# Altium schematic sheet nRF52840.SchDoc
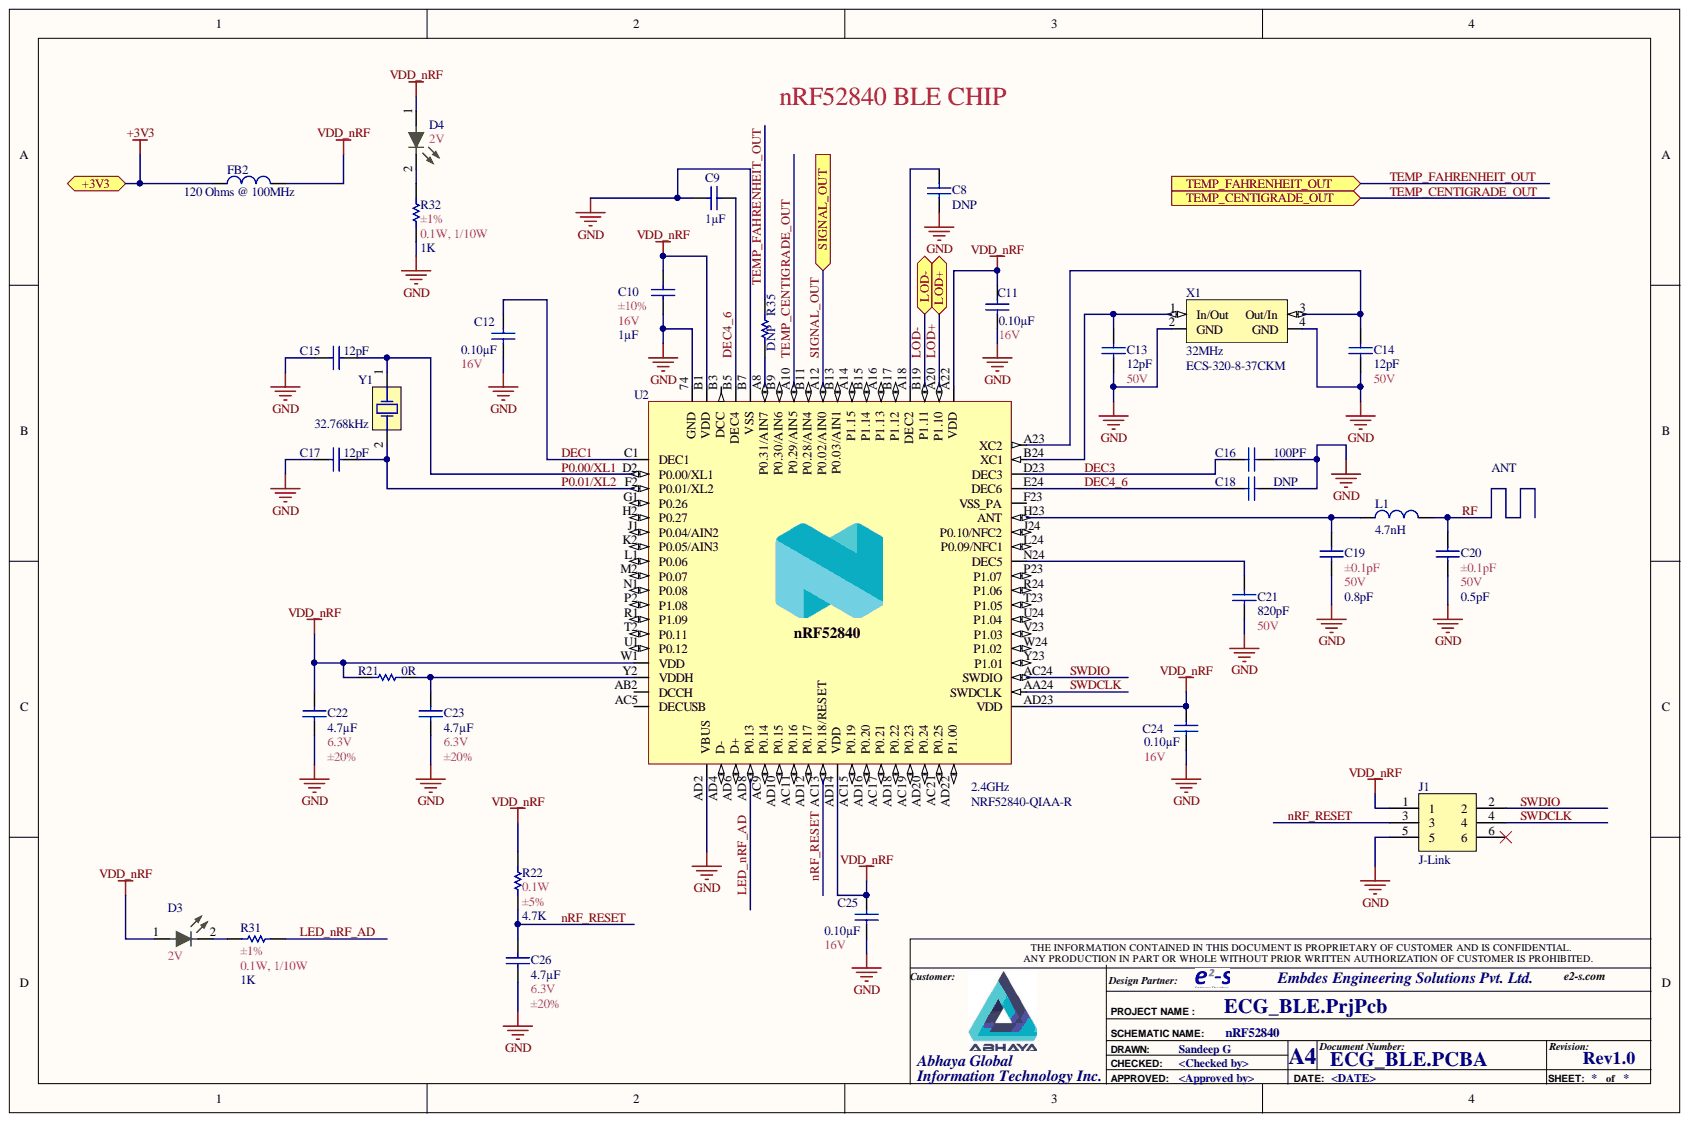
[diagram p0: nRF52840.SchDoc]

## Parts
C8  value DNP  Manufacturer Part Number: DNP  [SMDC0402]  (hidden comment: CAP CER 100PF 25V X7RCAP CER 100PF 25V C0G/NP0 0402 0402)
C9  value 1µF  [SMDC0402]  (hidden comment: CAP CER 1UF 16V X5R 0402)
C10  value 1µF  1st Auxiliary Field: 16V  2nd Auxiliary Field: ±10%  [SMDC0402]  (hidden comment: CAP CER 1UF 16V X5R 0402)
C11  value 0.10µF  1st Auxiliary Field: 16V  [SMDC0402]  (hidden comment: CAP CER 0.1UF 16V X7R 0402)
C12  value 0.10µF  1st Auxiliary Field: 16V  [SMDC0402]  (hidden comment: CAP CER 0.1UF 16V X7R 0402)
C13  value 12pF  1st Auxiliary Field: 50V  [SMDC0402]  (hidden comment: CAP CER 12PF 50V C0G/NP0 0402)
C14  value 12pF  1st Auxiliary Field: 50V  [SMDC0402]  (hidden comment: CAP CER 12PF 50V C0G/NP0 0402)
C15  value 12pF  [SMDC0402]  (hidden comment: CAP CER 12PF 50V C0G/NP0 0402)
C16  value 100PF  [SMDC0402]  (hidden comment: CAP CER 100PF 25V X7RCAP CER 100PF 25V C0G/NP0 0402 0402)
C17  value 12pF  [SMDC0402]  (hidden comment: CAP CER 12PF 50V C0G/NP0 0402)
C18  value DNP  Manufacturer Part Number: DNP  [SMDC0402]  (hidden comment: CAP CER 100PF 25V X7RCAP CER 100PF 25V C0G/NP0 0402 0402)
C19  value 0.8pF  1st Auxiliary Field: 50V  2nd Auxiliary Field: ±0.1pF  [SMDC0402]  (hidden comment: CAP CER 0.8PF 50V C0G/NP0 0402)
C20  value 0.5pF  1st Auxiliary Field: 50V  2nd Auxiliary Field: ±0.1pF  [SMDC0402]  (hidden comment: CAP CER 0.5PF 50V C0G/NP0 0402)
C21  value 820pF  1st Auxiliary Field: 50V  [SMDC0402]  (hidden comment: CAP CER 820PF 50V C0G/NP0 0402)
C22  value 4.7µF  1st Auxiliary Field: 6.3V  2nd Auxiliary Field: ±20%  [SMDC0402]  (hidden comment: CAP CER 4.7UF 6.3V X5R 0402)
C23  value 4.7µF  1st Auxiliary Field: 6.3V  2nd Auxiliary Field: ±20%  [SMDC0402]  (hidden comment: CAP CER 4.7UF 6.3V X5R 0402)
C24  value 0.10µF  1st Auxiliary Field: 16V  [SMDC0402]  (hidden comment: CAP CER 0.1UF 16V X7R 0402)
C25  value 0.10µF  1st Auxiliary Field: 16V  [SMDC0402]  (hidden comment: CAP CER 0.1UF 16V X7R 0402)
C26  value 4.7µF  1st Auxiliary Field: 6.3V  2nd Auxiliary Field: ±20%  [SMDC0402]  (hidden comment: CAP CER 4.7UF 6.3V X5R 0402)
D3  1st Auxiliary Field: 2V  [SMDLED0603]  (hidden comment: LED GREEN CLEAR SMD)
D4  1st Auxiliary Field: 2V  [SMDLED0603]  (hidden comment: LED GREEN CLEAR SMD)
FB2  value 120 Ohms @ 100MHz  [SMDL0402]  (hidden comment: FERRITE BEAD 120 OHM 0402 1LN)
J1  1st Auxiliary Field: J-Link  [PRG_8.06.16 J-LINK 6-PIN NEEDLE ADAPTER]  (hidden comment: J-LINK 6-PIN NEEDLE ADAPTER)
L1  value 4.7nH  [SMDL0402]  (hidden comment: FIXED IND 4.7NH 160MA 600 MOHM)
R21  value 0R  [SMDR0402]  (hidden comment: RES SMD 0.0OHM JUMPER 1/10W 0402)
R22  value 4.7K  1st Auxiliary Field: 0.1W  2nd Auxiliary Field: ±5%  [SMDR0402]  (hidden comment: RES SMD 4.7K OHM 5% 1/10W 0402)
R31  value 1K  1st Auxiliary Field: 0.1W, 1/10W  2nd Auxiliary Field: ±1%  [SMDR0402]  (hidden comment: RES SMD 1K OHM 1% 1/10W 0402)
R32  value 1K  2nd Auxiliary Field: ±1%  [SMDR0402]  (hidden comment: RES SMD 1K OHM 1% 1/10W 0402)
R35  value DNP  [SMDR0402]  (hidden comment: RES SMD 0.0OHM JUMPER 1/10W 0402)
U2  value NRF52840-QIAA-R  1st Auxiliary Field: 2.4GHz  Manufacturer Part Number: NRF52840-QIAA-R  [AQFN50P700X700X85_HS-74N]  (hidden comment: IC RF TXRX+MCU 802.15.4 73VFQFN)
X1  value ECS-320-8-37CKM  1st Auxiliary Field: 32MHz  Manufacturer Part Number: ECS-320-8-37CKM  [XTAL200X160X50-4N]  (hidden comment: CRYSTAL 32.0000MHZ 8PF SMD)
Y1  1st Auxiliary Field: 32.768kHz  [XTAL325X155X80N]  (hidden comment: CRYSTAL 32.7680KHZ 12.5PF SMD)

## Ports
+3V3, LOD+, LOD-, SIGNAL_OUT, TEMP_CENTIGRADE_OUT, TEMP_FAHRENHEIT_OUT

## Nets
GND: C8.2 C9.1 C10.1 C11.1 C12.1 C13.1 C14.1 C15.1 C16.2 C17.1 C18.2 C19.1 C20.1 C21.1 C22.1 C23.1 C24.1 C25.1 C26.1 J1.5 R32.2 U2.74(GND) U2.AD2(VBUS) U2.B7(VSS) X1.2(GND) X1.4(GND)
VDD_nRF: C10.2 C11.2 C22.2 C24.2 C25.2 D3.1 D4.1 FB2.2 J1.1 R21.1 R22.2 U2.A22(VDD) U2.AD14(VDD) U2.AD23(VDD) U2.B1(VDD) U2.W1(VDD)
+3V3: FB2.1 ; port +3V3
DEC1: C12.2 U2.C1(DEC1)
DEC3: C16.1 U2.D23(DEC3)
DEC4_6: C9.2 C18.1 U2.B5(DEC4) U2.E24(DEC6)
LED_nRF_AD: R31.1 U2.AD8(P0.13)
LOD+: U2.A20(P1.10) ; port LOD+
LOD-: U2.B19(P1.11) ; port LOD-
nRF_RESET: C26.2 J1.3 R22.1 U2.AC13(P0.18/RESET)
P0.00/XL1: C15.2 U2.D2(P0.00/XL1) Y1.1
P0.01/XL2: C17.2 U2.F2(P0.01/XL2) Y1.2
RF: C20.2 L1.2
SIGNAL_OUT: U2.A12(P0.02/AIN0) ; port SIGNAL_OUT
SWDCLK: J1.4 U2.AA24(SWDCLK)
SWDIO: J1.2 U2.AC24(SWDIO)
TEMP_CENTIGRADE_OUT: U2.A10(P0.29/AIN5) ; port TEMP_CENTIGRADE_OUT
TEMP_FAHRENHEIT_OUT: R35.2 ; port TEMP_FAHRENHEIT_OUT
NetC8_1: C8.1 U2.A18(DEC2)
NetC13_2: C13.2 U2.B24(XC1) X1.1(In/Out)
NetC14_2: C14.2 U2.A23(XC2) X1.3(Out/In)
NetC19_2: C19.2 L1.1 U2.H23(ANT)
NetC21_2: C21.2 U2.N24(DEC5)
NetC23_2: C23.2 R21.2 U2.Y2(VDDH)
NetD3_2: D3.2 R31.2
NetD4_2: D4.2 R32.1
NetR35_1: R35.1 U2.A8(P0.31/AIN7)

Not connected: J1 6; U2 A14(P1.15) A16(P1.13) AB2(DCCH) AC5(DECUSB) AC9(P0.14) AC11(P0.16) AC15(P0.19) AC17(P0.21) AC19(P0.23) AC21(P0.25) AD4(D-) AD6(D+) AD10(P0.15) AD12(P0.17) AD16(P0.20) AD18(P0.22) AD20(P0.24) AD22(P1.00) B3(DCC) B9(P0.30/AIN6) B11(P0.28/AIN4) B13(P0.03/AIN1) B15(P1.14) B17(P1.12) F23(VSS_PA) G1(P0.26) H2(P0.27) J1(P0.04/AIN2) J24(P0.10/NFC2) K2(P0.05/AIN3) L1(P0.06) L24(P0.09/NFC1) M2(P0.07) N1(P0.08) P2(P1.08) P23(P1.07) R1(P1.09) R24(P1.06) T2(P0.11) T23(P1.05) U1(P0.12) U24(P1.04) V23(P1.03) W24(P1.02) Y23(P1.01)

## Library data of the parts
C8 C18 = ECA100P0K25V0-SB: CAP CER 100PF 25V C0G/NP0 0402; Manufacturer: KEMET; Vendor Part Number: 399-1022-1-ND; 2nd Auxiliary Field: ±5%; 3rd Auxiliary Field: ROHS3 Compliant; Component Height (mm): 0.55mm; Temp Co: -55°C ~ 125°C; Vendor Name: Digi-Key; 1st Auxiliary Field: 25V; Component Kind: Standard; Internal Part Number: ECA100P0K25V0-SB; Library Name: Master_ Library.SchLib; Part Type: CAP CER 100PF 25V C0G/NP0 0402
C9 = ECA1U00L16V0-SB: Manufacturer: Samsung Electro-Mechanics; Manufacturer Part Number: CL05A105KO5NNNC; Vendor Part Number: 1276-1067-1-ND; 2nd Auxiliary Field: ±10%; 3rd Auxiliary Field: RoHS Compliant; Component Height (mm): 0.55MM; Vendor Name: Digi-Key; 1st Auxiliary Field: 16V; Component Kind: Standard; Description: CAP CER 1UF 16V X5R 0402; Internal Part Number: ECA1U00L16V0-SB; Library Name: Integrated_Master_Library.IntLib; Part Type: CAP CER 1UF 16V X5R 0402; Temp Co: X5R
C10 = ECA1U00L16V0-SB: Manufacturer: Samsung Electro-Mechanics; Manufacturer Part Number: CL05A105KO5NNNC; Vendor Part Number: 1276-1067-1-ND; 3rd Auxiliary Field: RoHS Compliant; Component Height (mm): 0.55MM; Vendor Name: Digi-Key; Component Kind: Standard; Description: CAP CER 1UF 16V X5R 0402; Internal Part Number: ECA1U00L16V0-SB; Library Name: Integrated_Master_Library.IntLib; Part Type: CAP CER 1UF 16V X5R 0402; Temp Co: X5R
C11 C12 C24 C25 = ECA0U10K16V0-1-SB: Manufacturer: Samsung Electro-Mechanics America, Inc.; Manufacturer Part Number: CL05B104KO5NNNC; Vendor Part Number: 1276-1001-1-ND; 2nd Auxiliary Field: ±10%; Vendor Name: Digi-Key; Component Kind: Standard; Description: CAP CER 0.1UF 16V X7R 0402; Internal Part Number: ECA0U10K16V0-1-SB; Library Name: Master_ Library.SchLib; Part Type: CAP CER 0.1UF 16V X7R 0402; Temp Co: X7R
C13-C15 C17 = ECA12P0K50V0-SB: Manufacturer: KEMET; Manufacturer Part Number: CBR04C120J5GAC; Vendor Part Number: 399-6169-1-ND; 2nd Auxiliary Field: ±5%; 3rd Auxiliary Field: RoHS Compliant; Component Height (mm): 0.55mm; Temp Co: -55°C ~ 125°C; Vendor Name: Digi-Key; Component Kind: Standard; Description: CAP CER 12PF 50V C0G/NP0 0402; Internal Part Number: ECA12P0K50V0-SB; Part Type: CAP CER 12PF 50V C0G/NP0 0402
  C13 C14: Library Name: Integrated_Master_Library.IntLib
  C15 C17: 1st Auxiliary Field: 50V; Library Name: Master_ Library.SchLib
C16 = ECA100P0K25V0-SB: CAP CER 100PF 25V C0G/NP0 0402; Manufacturer: KEMET; Manufacturer Part Number: C0402C101J3GACTU; Vendor Part Number: 399-1022-1-ND; 2nd Auxiliary Field: ±5%; 3rd Auxiliary Field: ROHS3 Compliant; Component Height (mm): 0.55mm; Temp Co: -55°C ~ 125°C; Vendor Name: Digi-Key; 1st Auxiliary Field: 25V; Component Kind: Standard; Internal Part Number: ECA100P0K25V0-SB; Library Name: Master_ Library.SchLib; Part Type: CAP CER 100PF 25V C0G/NP0 0402
C19 = ECA0P8W50V-SB: Internal Part Number: ECA00P8W50V-SB; Manufacturer: Murata Electronics; Manufacturer Part Number: GJM1555C1HR80BB01D; Vendor Part Number: 490-6080-1-ND; 3rd Auxiliary Field: ROHS3 Compliant; Component Height (mm): 0.55mm; Temp Co: C0G, NP0; Vendor Name: Digi-Key; Component Kind: Standard; Description: CAP CER 0.8PF 50V C0G/NP0 0402; Library Name: Master_ Library.SchLib; Part Type: CAP CER 0.8PF 50V C0G/NP0 0402
C20 = ECA0P50W50V0-SB: Manufacturer: Murata Electronics; Manufacturer Part Number: GJM1555C1HR50BB01D; Vendor Part Number: 490-6077-1-ND; 3rd Auxiliary Field: ROHS3 Compliant; Component Height (mm): 0.55mm; Temp Co: -55°C ~ 125°C; Vendor Name: Digi-Key; Component Kind: Standard; Description: CAP CER 0.5PF 50V C0G/NP0 0402; Internal Part Number: ECA0P50W50V0-SB; Part Type: CAP CER 0.5PF 50V C0G/NP0 0402; Library Name: ECG_BLE.SchLib
C21 = ECA820PK50V0-SB: Manufacturer: Samsung Electro-Mechanics; Manufacturer Part Number: CL05C821JB5NNNC; Vendor Part Number: 1276-1727-1-ND; 2nd Auxiliary Field: ±5%; 3rd Auxiliary Field: ROHS3 Compliant; Component Height (mm): 0.55mm; Temp Co: -55°C ~ 125°C; Vendor Name: Digi-Key; Component Kind: Standard; Description: CAP CER 820PF 50V C0G/NP0 0402; Internal Part Number: ECA820PK50V0-SB; Part Type: CAP CER 820PF 50V C0G/NP0 0402; Library Name: ECG_BLE.SchLib
C22 C23 C26 = ECA4U70N6V30-SB: Manufacturer: Murata Electronics North America; Manufacturer Part Number: GRM155R60J475ME47D; Vendor Part Number: 490-5915-1-ND; 3rd Auxiliary Field: RoHS Compliant; Component Height (mm): 0.60mm; Temp Co: -55°C ~ 85°C; Vendor Name: Digi-Key; Component Kind: Standard; Description: CAP CER 4.7UF 6.3V X5R 0402; Internal Part Number: ECA4U70N6V30-SB; Library Name: Integrated_Master_Library.IntLib; Part Type: CAP CER 4.7UF 6.3V X5R 0402
D3 D4 = ELDG2V0020M0-SC: Manufacturer: Lite-On Inc.; Manufacturer Part Number: LTST-C191KGKT; Vendor Part Number: 160-1446-1-ND; 2nd Auxiliary Field: 20mA; 3rd Auxiliary Field: RoHS Compliant; Component Height (mm): 0.55mm; Value: LTST-C191KGKT; Vendor Name: Digi-Key; Component Kind: Standard; Description: LED GREEN CLEAR SMD; Internal Part Number: ELDG2V0020M0-SC; Library Name: Master_ Library.SchLib; Part Type: LED GREEN CLEAR SMD
FB2 = EMS-BLM15PX121SN1D: Manufacturer: Murata Electronics; Vendor Part Number: 490-9656-1-ND; 1st Auxiliary Field: 2A; 2nd Auxiliary Field: 55mOhm; 3rd Auxiliary Field: ROHS3 Compliant; Component Height (mm): 0.55mm; Temp Co: -55°C ~ 125°C; Vendor Name: Digi-Key; Component Kind: Standard; Description: FERRITE BEAD 120 OHM 0402 1LN; Internal Part Number: EMS-BLM15PX121SN1D; Manufacturer Part Number: BLM15PX121SN1D; Part Type: FERRITE BEAD 120 OHM 0402 1LN; Library Name: ECG_BLE.SchLib
J1 = EMS-8.06.16 J-LINK 6-PIN NEEDLE ADAPTER: Manufacturer: Segger Microcontroller Systems; Vendor Part Number: 899-1051-ND; 3rd Auxiliary Field: RoHS Compliant; Vendor Name: Digi-Key; Component Kind: Standard; Description: J-LINK 6-PIN NEEDLE ADAPTER; Internal Part Number: EMS-8.06.16 J-LINK 6-PIN NEEDLE ADAPTER; Library Name: Integrated_Master_Library.IntLib; Manufacturer Part Number: 8.06.16 J-LINK 6-PIN NEEDLE ADAPTER; Part Type: J-LINK 6-PIN NEEDLE ADAPTER; Value: 8.06.16 J-LINK 6-PIN NEEDLE ADAPTER
L1 = EIN4N70T160M-SB: Manufacturer: Murata Electronics; Manufacturer Part Number: LQP15MN4N7B02D; Vendor Part Number: 490-1132-1-ND; 2nd Auxiliary Field: 600mOhm Max; 3rd Auxiliary Field: ROHS3 Compliant; Component Height (mm): 0.45mm; Temp Co: -40°C ~ 85°C; Vendor Name: Digi-Key; 1st Auxiliary Field: 160mA; Component Kind: Standard; Description: FIXED IND 4.7NH 160MA 600 MOHM; Internal Part Number: EIN4N70T160M-SB; Part Type: FIXED IND 4.7NH 160MA 600 MOHM; Library Name: ECG_BLE.SchLib
R21 R35 = ERE0R00110-SB: Manufacturer: Panasonic Electronic Components; Manufacturer Part Number: ERJ-2GE0R00X; Vendor Part Number: P0.0JCT-ND; 1st Auxiliary Field: 0.1W; Component Height (mm): 0.40mm; Vendor Name: Digi-Key; 2nd Auxiliary Field: Jumper; Component Kind: Standard; Description: RES SMD 0.0OHM JUMPER 1/10W 0402; Internal Part Number: ERE0R00110-SB; Library Name: Integrated_Master_Library.IntLib; Part Type: RES SMD 0.0OHM JUMPER 1/10W 0402
R22 = ERE4K70J110-SB: Manufacturer: Panasonic Electronic Components; Manufacturer Part Number: ERJ-2GEJ472X; Vendor Part Number: P4.7KJCT-ND; Component Height (mm): 0.40mm; Temp Co: ±200ppm/°C; Vendor Name: Digi-Key; Component Kind: Standard; Description: RES SMD 4.7K OHM 5% 1/10W 0402; Internal Part Number: ERE4K70J110-SB; Library Name: Integrated_Master_Library.IntLib; Part Type: RES SMD 4.7K OHM 5% 1/10W 0402
R31 = ERE1K00G110-SB_1: Manufacturer: Panasonic Electronic Components; Manufacturer Part Number: ERJ-2RKF1001X; Vendor Part Number: P1.00KLCT-ND; 3rd Auxiliary Field: RoHS Compliant; Component Height (mm): 0.40mm; Temp Co: ±100ppm/°C; Vendor Name: Digi-Key; Component Kind: Standard; Description: RES SMD 1K OHM 1% 1/10W 0402; Internal Part Number: ERE1K00G110-SB; Library Name: Master_ Library.SchLib; Part Type: RES SMD 1K OHM 1% 1/10W 0402
R32 = ERE1K00G110-SB_1: Manufacturer: Panasonic Electronic Components; Manufacturer Part Number: ERJ-2RKF1001X; Vendor Part Number: P1.00KLCT-ND; 1st Auxiliary Field: 0.1W, 1/10W; 3rd Auxiliary Field: RoHS Compliant; Component Height (mm): 0.40mm; Temp Co: ±100ppm/°C; Vendor Name: Digi-Key; Component Kind: Standard; Description: RES SMD 1K OHM 1% 1/10W 0402; Internal Part Number: ERE1K00G110-SB; Library Name: Master_ Library.SchLib; Part Type: RES SMD 1K OHM 1% 1/10W 0402
U2 = EICNSVFQFN-73-****: Manufacturer: Nordic Semiconductor ASA; Vendor Part Number: 1490-1071-1-ND; 2nd Auxiliary Field: 1.7V ~ 5.5V; 3rd Auxiliary Field: RoHS Compliant; 4th Auxiliary Field: 2Mbps; Component Height (mm): 0.85mm; Temp Co: -40°C ~ 85°C; Vendor Name: Digi-Key; Component Kind: Standard; Description: IC RF TXRX+MCU 802.15.4 73VFQFN; Internal Part Number: EICNSVFQFN-73-****; Part Type: IC RF TXRX+MCU 802.15.4 73VFQFN; Library Name: ECG_BLE.SchLib
X1 = EMS-ECS-320-8-37CKM: Manufacturer: ECS Inc.; Vendor Part Number: XC1547CT-ND; 3rd Auxiliary Field: RoHS Compliant; Component Height (mm): 0.55mm; Temp Co: -20°C ~ 70°C; Vendor Name: Digi-Key; 2nd Auxiliary Field: 8pF; Component Kind: Standard; Description: CRYSTAL 32.0000MHZ 8PF SMD; Internal Part Number: EMS-ECS-320-8-37CKM; Library Name: Integrated_Master_Library.IntLib; Part Type: CRYSTAL 32.0000MHZ 8PF SMD
Y1 = EMS-ABS07-32.768KHZ-T: Manufacturer: Abracon LLC; Vendor Part Number: 535-9542-1-ND; 2nd Auxiliary Field: ±20ppm; 3rd Auxiliary Field: RoHS Compliant; Component Height (mm): 0.9mm; Temp Co: -40°C ~ 85°C; Vendor Name: Digi-Key; Component Kind: Standard; Description: CRYSTAL 32.7680KHZ 12.5PF SMD; Internal Part Number: EMS-ABS07-32.768KHZ-T; Library Name: Master_ Library.SchLib; Manufacturer Part Number: ABS07-32.768KHZ-T; Part Type: CRYSTAL 32.7680KHZ 12.5PF SMD; Value: ABS07-32.768KHZ-T
